# Altium schematic sheet ETH.SchDoc
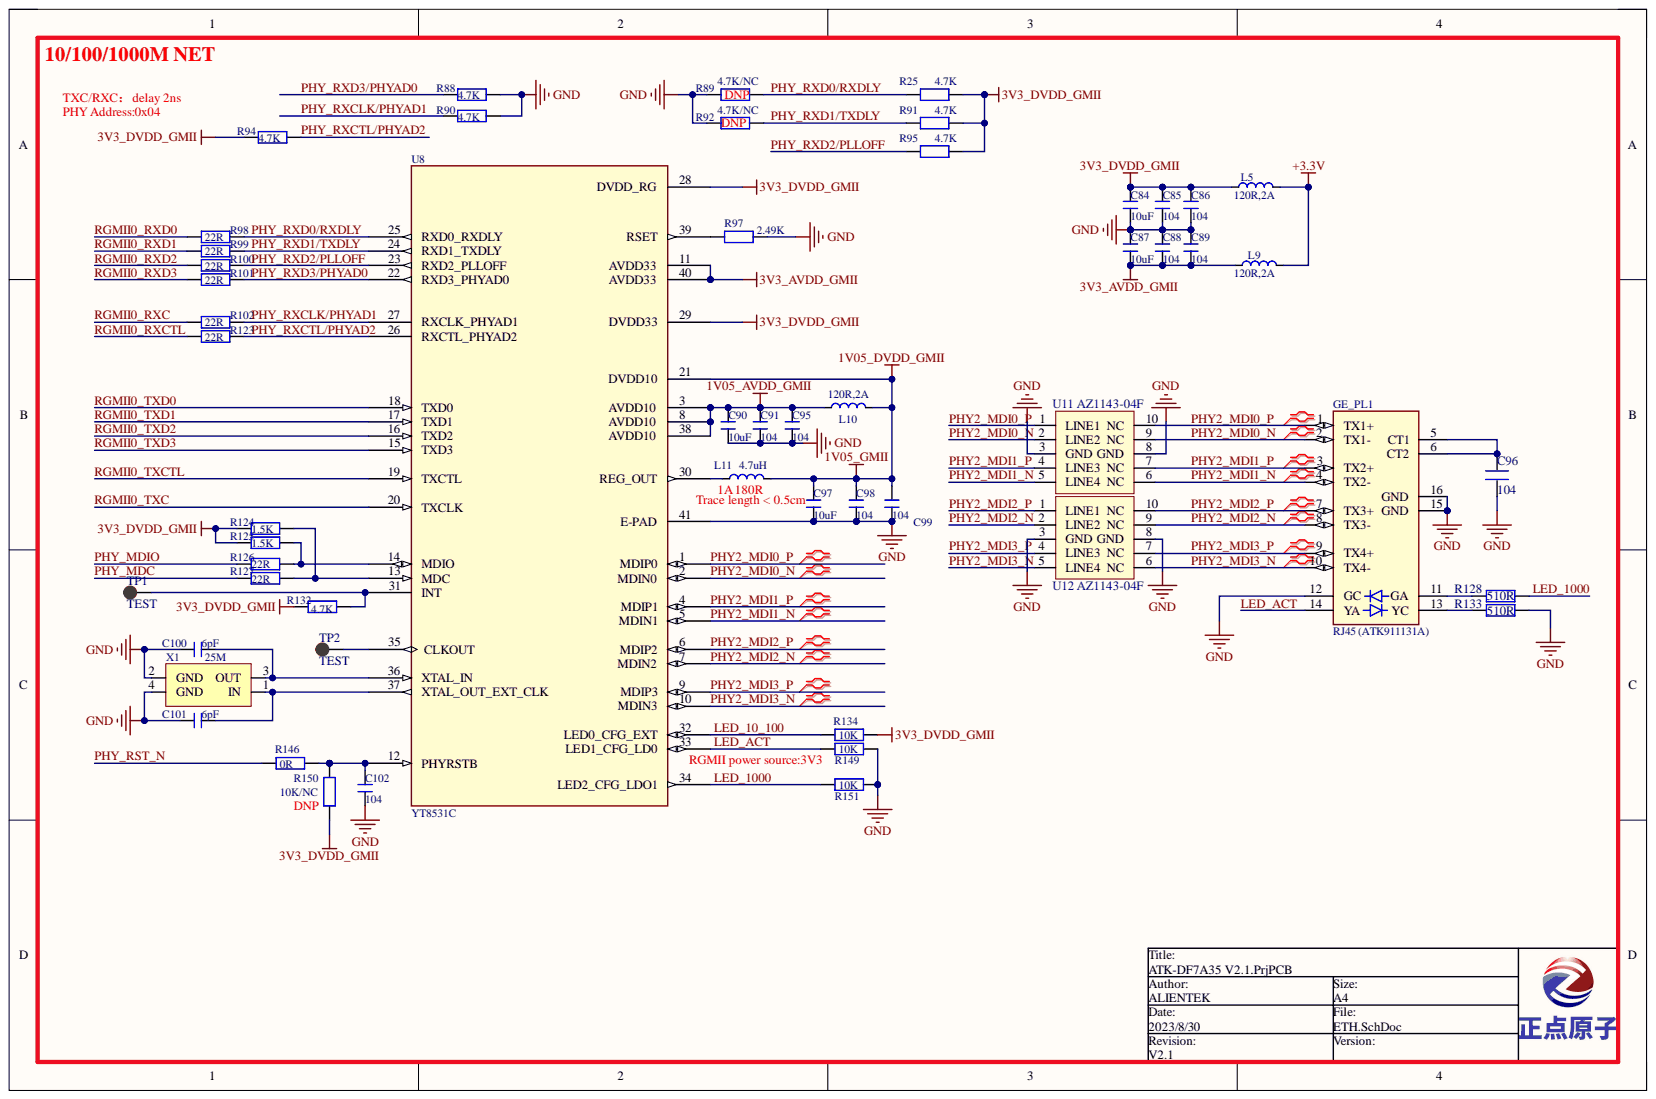
[diagram p0: ETH.SchDoc]

## Parts
C84  10uF  [C 0603]
C85  104  [C 0603]
C86  104  [C 0603]
C87  10uF  [C 0603]
C88  104  [C 0603]
C89  104  [C 0603]
C90  10uF  [C 0603]
C91  104  [C 0603]
C95  104  [C 0603]
C96  104  [C 0603]
C97  10uF  [C 0603]
C98  104  [C 0603]
C99  104  [C 0603]
C100  6pF  [C 0603]
C101  6pF  [C 0603]
C102  104  [C 0603]
GE_PL1  RJ45 (ATK911131A)  [HR911131A]
L5  120R,2A  [L 0603]
L9  120R,2A  [L 0603]
L10  120R,2A  [L 0603]
L11  4.7uH  [L-2520]
R25  4.7K  [R 0603]
R88  4.7K  [R 0603]
R89  4.7K/NC  [R 0603]
R90  4.7K  [R 0603]
R91  4.7K  [R 0603]
R92  4.7K/NC  [R 0603]
R94  4.7K  [R 0603]
R95  4.7K  [R 0603]
R97  2.49K  [R 0603]
R98  22R  [R 0603]
R99  22R  [R 0603]
R100  22R  [R 0603]
R101  22R  [R 0603]
R102  22R  [R 0603]
R123  22R  [R 0603]
R124  1.5K  [R 0603]
R125  1.5K  [R 0603]
R126  22R  [R 0603]
R127  22R  [R 0603]
R128  510R  [R 0603]
R132  4.7K  [R 0603]
R133  510R  [R 0603]
R134  10K  [R 0603]
R146  0R  [R 0603]
R149  10K  [R 0603]
R150  10K/NC  [R 0603]
R151  10K  [R 0603]
TP1  TEST  [TEST 1.2]
TP2  TEST  [TEST 1.2]
U8  YT8531C  [QFN40 5X5_N]
U11  AZ1143-04F  [DFN2510P10E]
U12  AZ1143-04F  [DFN2510P10E]
X1  25M  [XTAL-3225]

## Nets
1V05_AVDD_GMII: C90.2 C91.2 C95.2 L10.1 U8.3(AVDD10) U8.8(AVDD10) U8.38(AVDD10)
1V05_DVDD_GMII: C97.2 C98.2 C99.2 L10.2 L11.2 U8.21(DVDD10)
3V3_AVDD_GMII: C87.1 C88.1 C89.1 L9.1 U8.11(AVDD33) U8.40(AVDD33)
3V3_DVDD_GMII: C84.2 C85.2 C86.2 L5.1 R25.2 R91.2 R94.2 R95.2 R124.1 R125.1 R132.1 R134.2 R150.2 U8.28(DVDD_RG) U8.29(DVDD33)
+3.3V: L5.2 L9.2
GND: C84.1 C85.1 C86.1 C87.2 C88.2 C89.2 C90.1 C91.1 C95.1 C96.1 C97.1 C98.1 C99.1 C100.2 C101.2 C102.1 GE_PL1.12(GC) GE_PL1.15(GND) GE_PL1.16(GND) R88.2 R89.1 R90.1 R92.1 R97.2 R133.2 R149.2 R151.2 U8.41(E-PAD) U11.3(GND) U11.8(GND) U12.3(GND) U12.8(GND) X1.2(GND) X1.4(GND)
LED_10_100: R134.1 U8.32(LED0_CFG_EXT)
LED_1000: R128.2 R151.1 U8.34(LED2_CFG_LDO1)
LED_ACT: GE_PL1.14(YA) R149.1 U8.33(LED1_CFG_LD0)
PHY2_MDI0_N: GE_PL1.2(TX1-) U8.2(MDIN0) U11.2(LINE2) U11.9(NC)
PHY2_MDI0_P: GE_PL1.1(TX1+) U8.1(MDIP0) U11.1(LINE1) U11.10(NC)
PHY2_MDI1_N: GE_PL1.4(TX2-) U8.5(MDIN1) U11.5(LINE4) U11.6(NC)
PHY2_MDI1_P: GE_PL1.3(TX2+) U8.4(MDIP1) U11.4(LINE3) U11.7(NC)
PHY2_MDI2_N: GE_PL1.8(TX3-) U8.7(MDIN2) U12.2(LINE2) U12.9(NC)
PHY2_MDI2_P: GE_PL1.7(TX3+) U8.6(MDIP2) U12.1(LINE1) U12.10(NC)
PHY2_MDI3_N: GE_PL1.10(TX4-) U8.10(MDIN3) U12.5(LINE4) U12.6(NC)
PHY2_MDI3_P: GE_PL1.9(TX4+) U8.9(MDIP3) U12.4(LINE3) U12.7(NC)
PHY_MDC: R127.1
PHY_MDIO: R126.1
PHY_RST_N: R146.1
PHY_RXCLK/PHYAD1: R90.2 R102.1 U8.27(RXCLK_PHYAD1)
PHY_RXCTL/PHYAD2: R94.1 R123.1 U8.26(RXCTL_PHYAD2)
PHY_RXD0/RXDLY: R25.1 R89.2 R98.1 U8.25(RXD0_RXDLY)
PHY_RXD1/TXDLY: R91.1 R92.2 R99.1 U8.24(RXD1_TXDLY)
PHY_RXD2/PLLOFF: R95.1 R100.1 U8.23(RXD2_PLLOFF)
PHY_RXD3/PHYAD0: R88.1 R101.1 U8.22(RXD3_PHYAD0)
RGMII0_RXC: R102.2
RGMII0_RXCTL: R123.2
RGMII0_RXD0: R98.2
RGMII0_RXD1: R99.2
RGMII0_RXD2: R100.2
RGMII0_RXD3: R101.2
RGMII0_TXC: U8.20(TXCLK)
RGMII0_TXCTL: U8.19(TXCTL)
RGMII0_TXD0: U8.18(TXD0)
RGMII0_TXD1: U8.17(TXD1)
RGMII0_TXD2: U8.16(TXD2)
RGMII0_TXD3: U8.15(TXD3)
NetC96_2: C96.2 GE_PL1.5(CT1) GE_PL1.6(CT2)
NetC100_1: C100.1 U8.36(XTAL_IN) X1.3(OUT)
NetC101_1: C101.1 U8.37(XTAL_OUT_EXT_CLK) X1.1(IN)
NetC102_2: C102.2 R146.2 R150.1 U8.12(PHYRSTB)
NetGE_PL1_11: GE_PL1.11(GA) R128.1
NetGE_PL1_13: GE_PL1.13(YC) R133.1
NetL11_1: L11.1 U8.30(REG_OUT)
NetR97_1: R97.1 U8.39(RSET)
NetR124_2: R124.2 R127.2 U8.13(MDC)
NetR125_2: R125.2 R126.2 U8.14(MDIO)
NetR132_2: R132.2 TP1.1 U8.31(INT)
NetTP2_1: TP2.1 U8.35(CLKOUT)

## Library data of the parts
C84 C87 C97 = C: 无极性贴片电容; Component Kind: Standard; Library Name: Lycreturn.IntLib
C85 C86 C88-C91 C95 C98-C102 = C: 无极性贴片电容; 版权归属: 源创客; BOM名称: 无极性贴片电容; Component Kind: Standard; 学习资源: www.ooocke.com; Library Name: Lycreturn.IntLib
C96 = C: Component Kind: Standard; Library Name: IMX6UL_ALPHA_V1.4.IntLib
GE_PL1 = HR911131A/C: 千兆以太网接口; Component Kind: Standard; Library Name: 接口类.SchLib
L5 L9 L10 = L: 小功率贴片电感磁珠; 版权归属: 源创客; BOM名称: 小功率贴片电感; Component Kind: Standard; Library Name: 电阻-电容-电感.SchLib; 学习资源: www.ooocke.com
L11 = L: 小功率贴片电感磁珠; Component Kind: Standard; Library Name: 电阻-电容-电感.SchLib
R25 R88-R92 R94 R95 R97-R102 R123-R127 R132 R134 R146 R149-R151 = R: 贴片电阻; 版权归属: 源创客; BOM名称: 贴片电阻; Component Kind: Standard; 学习资源: www.ooocke.com; Library Name: Lycreturn.IntLib
R128 R133 = R: 贴片电阻; 版权归属: 正点原子; BOM名称: 贴片电阻; Component Kind: Standard; 学习资源: www.openedv.com; Library Name: Lycreturn.IntLib
TP1 TP2 = TEST: 测试点; Parameter: 未分类器件; 版权归属: 正点原子; BOM名称: 测试点; Component Kind: Standard; Library Name: 正点原子封装库V1.0.IntLib; 学习资源: www.openedv.com
U8 = RTL8211F-CG: Component Kind: Standard; Library Name: ZZK_COMPONENT.IntLib
U11 U12 = AZ1143-04F: ESD保护二极管阵列; Component Kind: Standard; Library Name: 二极管-整流桥.SchLib
X1 = XTAL-4P: 4脚无源晶振; Component Kind: Standard; Library Name: Lycreturn.IntLib
